# Altium schematic sheet RGBW_controller.SchDoc
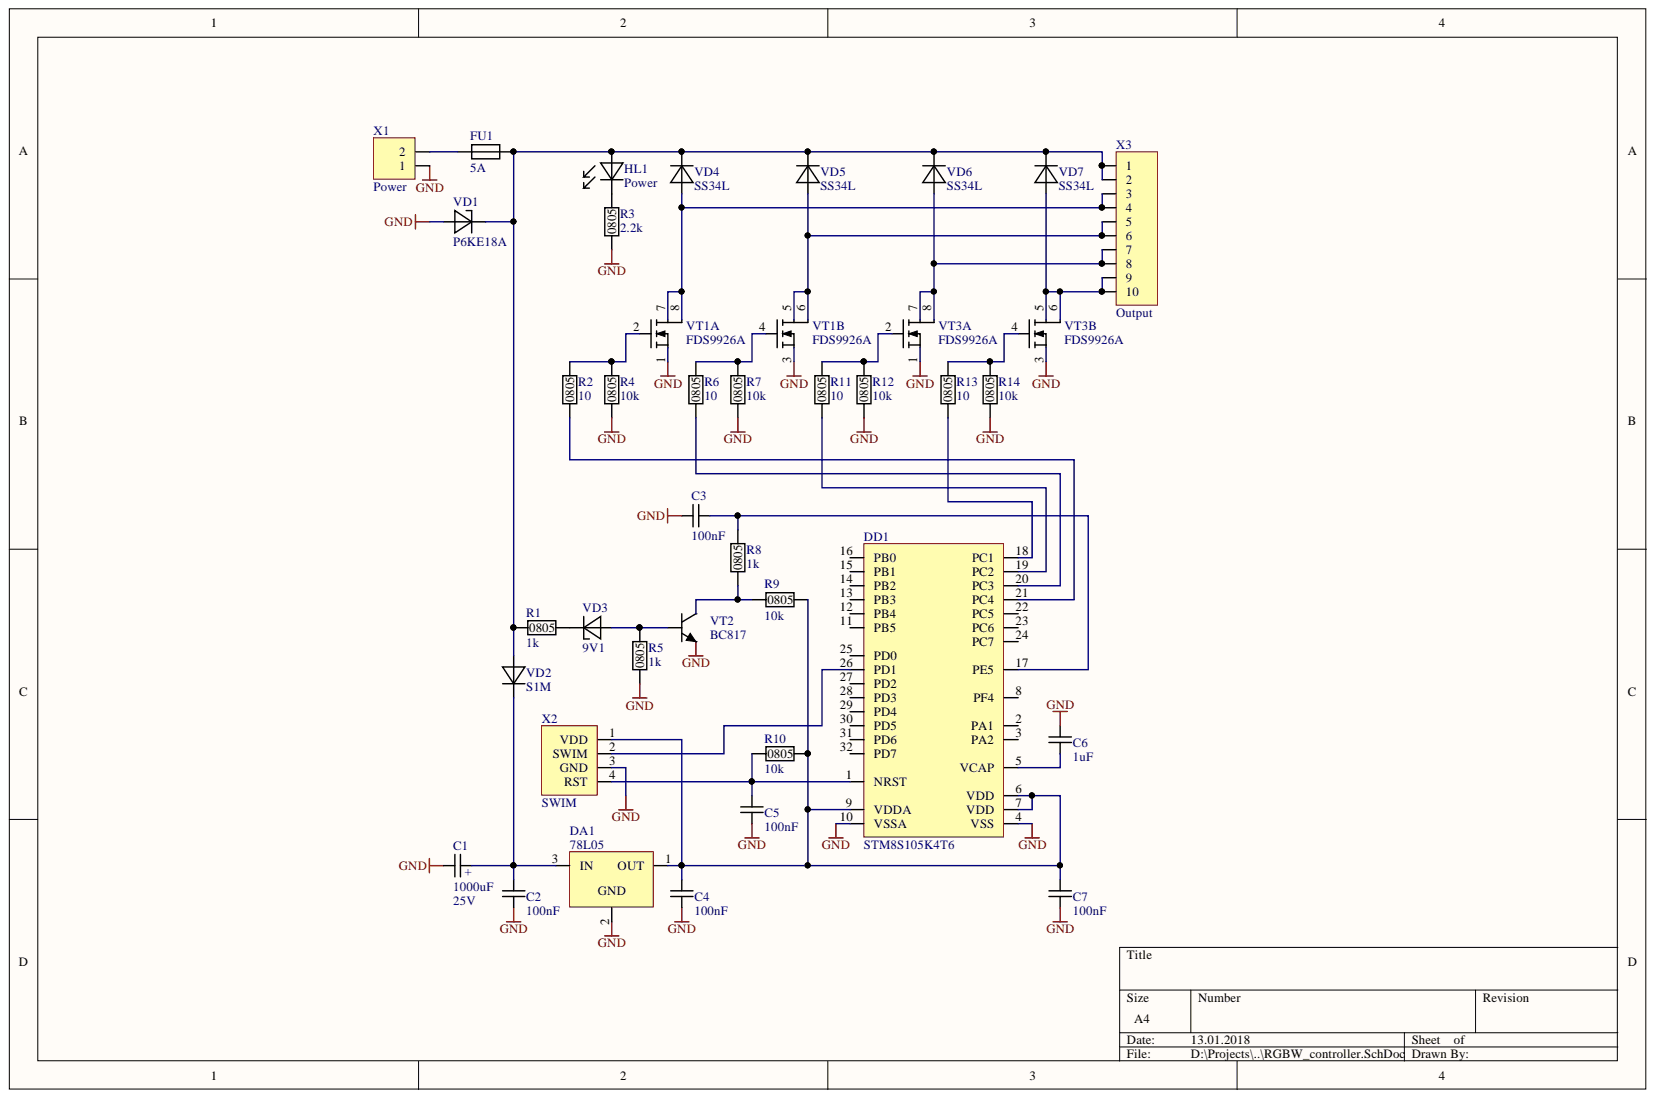
[diagram p0: RGBW_controller.SchDoc]

## Parts
C1  1000uF  Voltage: 25V  [CapEl 10x20x5 axial]
C2  100nF  [C0805]
C3  100nF  [C0805]
C4  100nF  [C0805]
C5  100nF  [C0805]
C6  1uF  [C0805]
C7  100nF  [C0805]
DA1  78L05  [TO92 line]
DD1  STM8S105K4T6  [TQFP32]
FU1  5A  [Fuse 4x10]
HL1  Power  [LED 3mm green]
R1  1k  [R0805]
R2  10  [R0805]
R3  2.2k  [R0805]
R4  10k  [R0805]
R5  1k  [R0805]
R6  10  [R0805]
R7  10k  [R0805]
R8  1k  [R0805]
R9  10k  [R0805]
R10  10k  [R0805]
R11  10  [R0805]
R12  10k  [R0805]
R13  10  [R0805]
R14  10k  [R0805]
VD1  P6KE18A  [DO15]
VD2  S1M  [SMA]
VD3  9V1  [SOD80]
VD4  SS34L  [SMA]
VD5  SS34L  [SMA]
VD6  SS34L  [SMA]
VD7  SS34L  [SMA]
VT1  FDS9926A  [SO8]  (parts A,B)
VT2  BC817  [SOT23-3]
VT3  FDS9926A  [SO8]  (parts A,B)
X1  Power  [DG301-5,0-2P]
X2  SWIM  [PLS4]
X3  Output  [DG380-3,81-10P]

## Nets
GND: C1.2 C2.2 C3.2 C4.2 C5.1 C6.2 C7.1 DA1.2 DD1.4(VSS) DD1.10(VSSA) R3.1 R4.1 R5.1 R7.1 R12.1 R14.1 VD1.1 VT1.1 VT1.3 VT2.2 VT3.1 VT3.3 X1.1 X2.3(GND)
NetC1_1: C1.1 C2.1 DA1.3 VD2.2
NetC3_1: C3.1 DD1.17(PE5) R8.2
NetC4_1: C4.1 C7.2 DA1.1 DD1.6(VDD) DD1.7(VDD) DD1.9(VDDA) R9.2 R10.2 X2.1(VDD)
NetC5_2: C5.2 DD1.1(NRST) R10.1 X2.4(RST)
NetC6_1: C6.1 DD1.5(VCAP)
NetDD1_18: DD1.18(PC1) R13.1
NetDD1_19: DD1.19(PC2) R11.1
NetDD1_20: DD1.20(PC3) R6.1
NetDD1_21: DD1.21(PC4) R2.1
NetDD1_26: DD1.26(PD1) X2.2
NetFU1_1: FU1.1 X1.2
NetFU1_2: FU1.2 HL1.1 R1.1 VD1.2 VD2.1 VD4.2 VD5.2 VD6.2 VD7.2 X3.1 X3.2
NetHL1_2: HL1.2 R3.2
NetR1_2: R1.2 VD3.2
NetR2_2: R2.2 R4.2 VT1.2
NetR5_2: R5.2 VD3.1 VT2.1
NetR6_2: R6.2 R7.2 VT1.4
NetR8_1: R8.1 R9.1 VT2.3
NetR11_2: R11.2 R12.2 VT3.2
NetR13_2: R13.2 R14.2 VT3.4
NetVD4_1: VD4.1 VT1.7 VT1.8 X3.3 X3.4
NetVD5_1: VD5.1 VT1.5 VT1.6 X3.5 X3.6
NetVD6_1: VD6.1 VT3.7 VT3.8 X3.7 X3.8
NetVD7_1: VD7.1 VT3.5 VT3.6 X3.9 X3.10

Not connected: DD1 2(PA1) 3(PA2) 8(PF4) 11(PB5) 12(PB4) 13(PB3) 14(PB2) 15(PB1) 16(PB0) 22(PC5) 23(PC6) 24(PC7) 25(PD0) 27(PD2) 28(PD3) 29(PD4) 30(PD5) 31(PD6) 32(PD7)

## Library data of the parts
C1 = CapEL_10x20x5_axial: Electrolytic capacitor; Database Table Name: Capacitors$; Component Kind: Standard; Library Name: Library.DbLib; Part Number: CapEL_10x20x5_axial
C2-C7 = C0805: 0805 SMD capacitors; Database Table Name: Capacitors$; Component Kind: Standard; Library Name: Library.DbLib; Part Number: C0805
DA1 = L78L: Positive Linear Voltage Regulator; Database Table Name: ICs$; Component Kind: Standard; Library Name: Library.DbLib; Part Number: L78L
DD1 = STM8S105K4T6: STM8 MCU with 16kB Flash; Database Table Name: ICs$; Component Kind: Standard; Library Name: Library.DbLib; Part Number: STM8S105K4T6
FU1 = Fuse_4x10: PCB Leaded Fuse 4x10 15mm pitch; Database Table Name: Fuses$; Component Kind: Standard; Library Name: Library.DbLib; Part Number: Fuse_4x10
HL1 = LED 3mm green: 3mm DIP green LED; Database Table Name: Indicators$; Component Kind: Standard; Library Name: Library.DbLib; Part Number: LED 3mm green
R1-R14 = R0805: 0805 SMD 1/8W resistor; Database Table Name: Resistors$; Component Kind: Standard; Library Name: Library.DbLib; Part Number: R0805
VD1 = P6KE18A: 18V 600W THT Unidirectional Supressor; Database Table Name: Diodes$; Component Kind: Standard; Library Name: Library.DbLib; Part Number: P6KE18A
VD2 = S1M: 1A Surface Mount Rectifier; Database Table Name: Diodes$; Component Kind: Standard; Library Name: Library.DbLib; Part Number: S1M
VD3 = BZV55: 500mW SMD Zener Diode; Database Table Name: Diodes$; Component Kind: Standard; Library Name: Library.DbLib; Part Number: BZV55
VD4-VD7 = SS34L: 3A Low Case Schottky Barrier Diode; Database Table Name: Diodes$; Component Kind: Standard; Library Name: Library.DbLib; Part Number: SS34L
VT1 VT3 = FDS9926A: Dual 20V 6.5A SO8 SMD n-MOSFET transistor with Rds=30mOhm; Database Table Name: Transistors$; Component Kind: Standard; Library Name: Library.DbLib; Part Number: FDS9926A
VT2 = BC817: 45V 500mA SOT23-3 SMD NPN transistor with h21>100; Database Table Name: Transistors$; Component Kind: Standard; Library Name: Library.DbLib; Part Number: BC817
X1 = DG301-5,0-2P: 2 pin Screw Terminal PCB Block; Database Table Name: Connectors$; Component Kind: Standard; Library Name: Library.DbLib; Part Number: DG301-5,0-2P
X2 = SWIM: 4 pin SWIM connector for STM8 MCU programming; Database Table Name: Connectors$; Component Kind: Standard; Library Name: Library.DbLib; Part Number: SWIM
X3 = DG380-3,81-10P: 10 pin Screw Terminal PCB Block; Database Table Name: Connectors$; Component Kind: Standard; Library Name: Library.DbLib; Part Number: DG380-3,81-10P
